# Altium schematic sheet Peripherals.SchDoc(Peripherals)
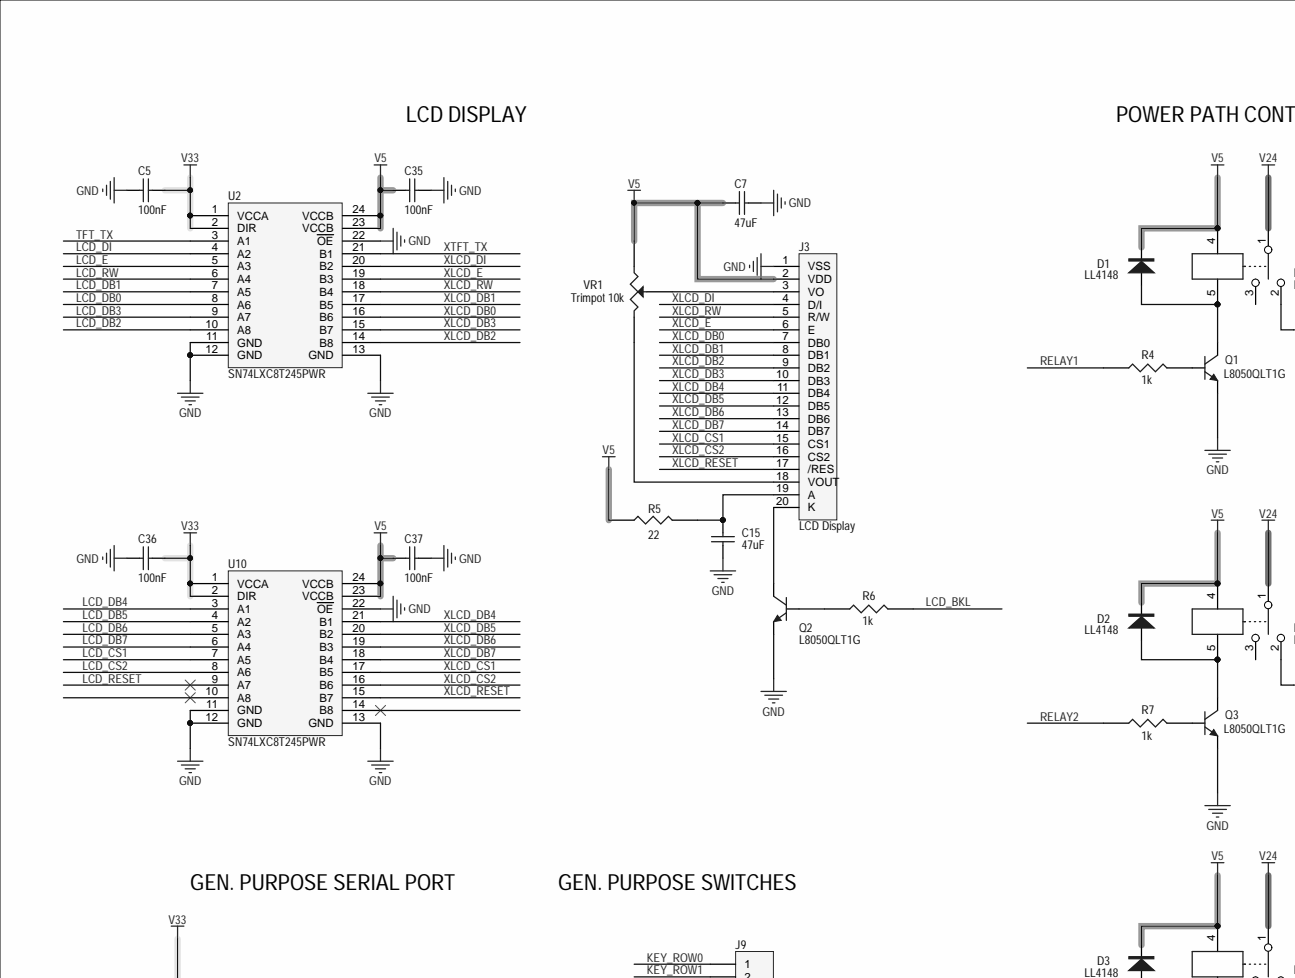
[diagram p0: Peripherals.SchDoc, top left]
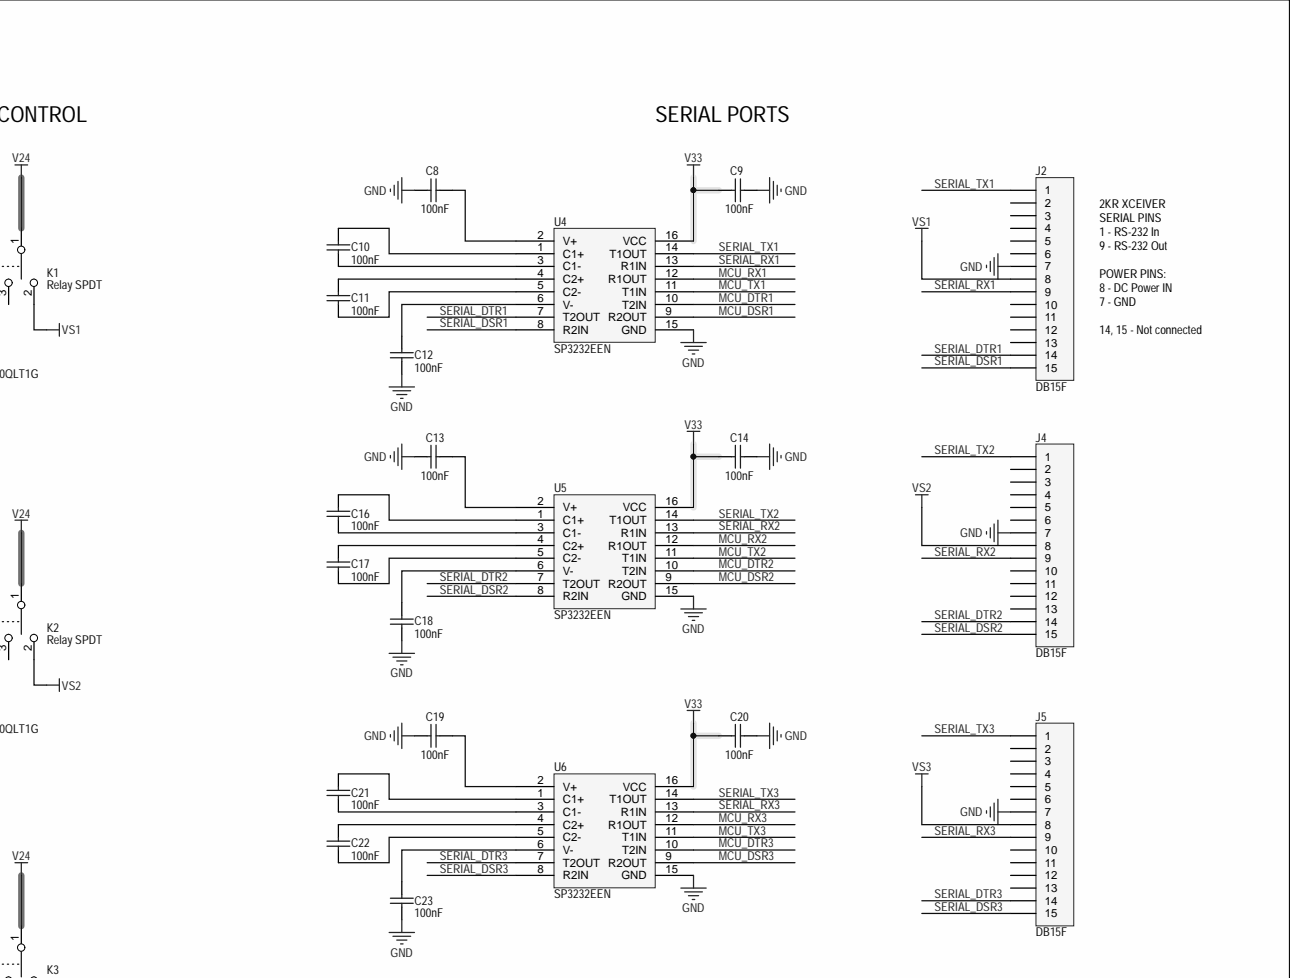
[diagram p1: Peripherals.SchDoc, top right]
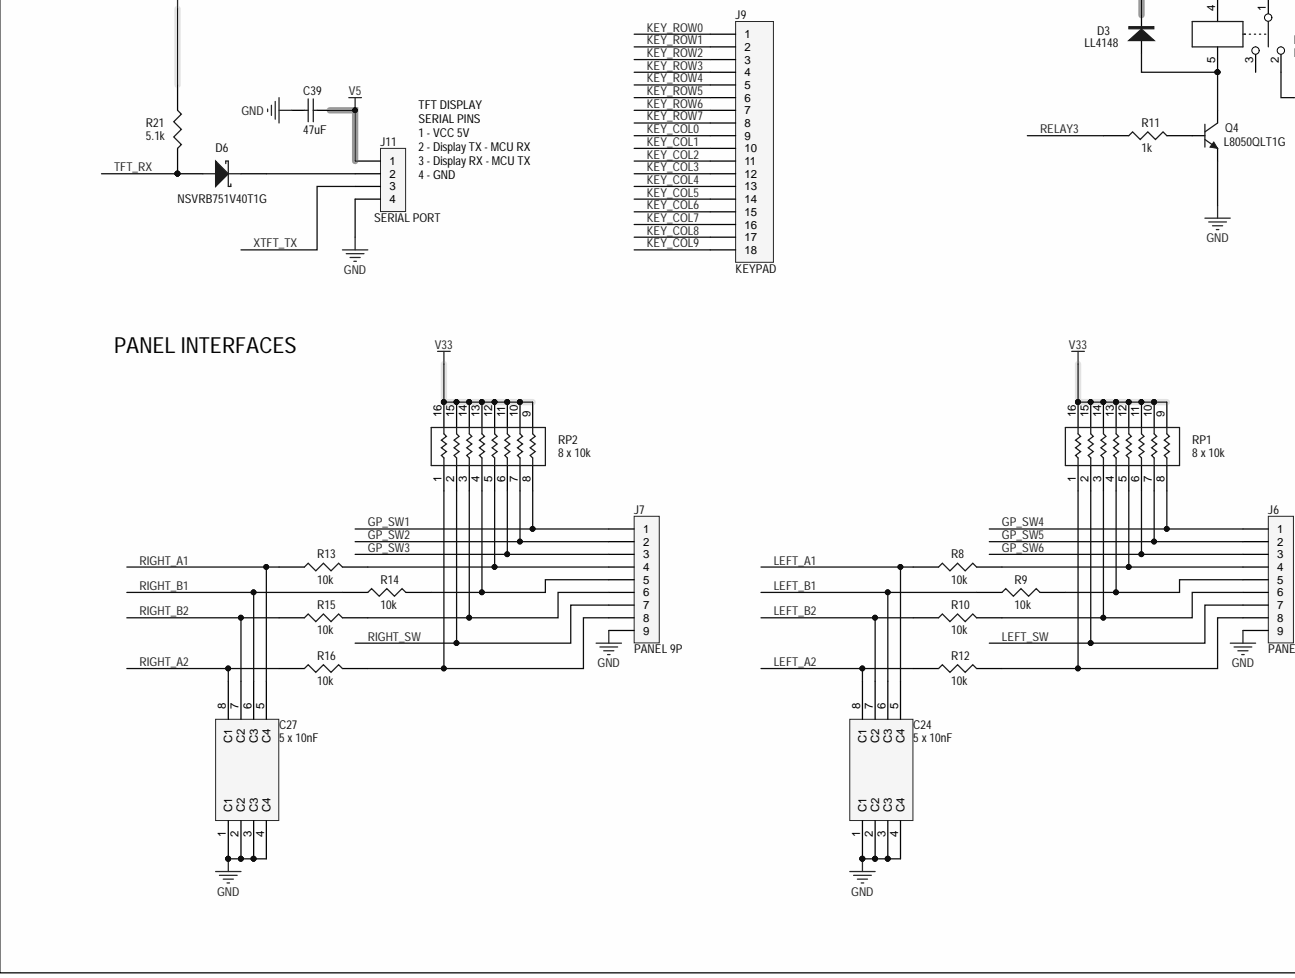
[diagram p2: Peripherals.SchDoc, bottom left]
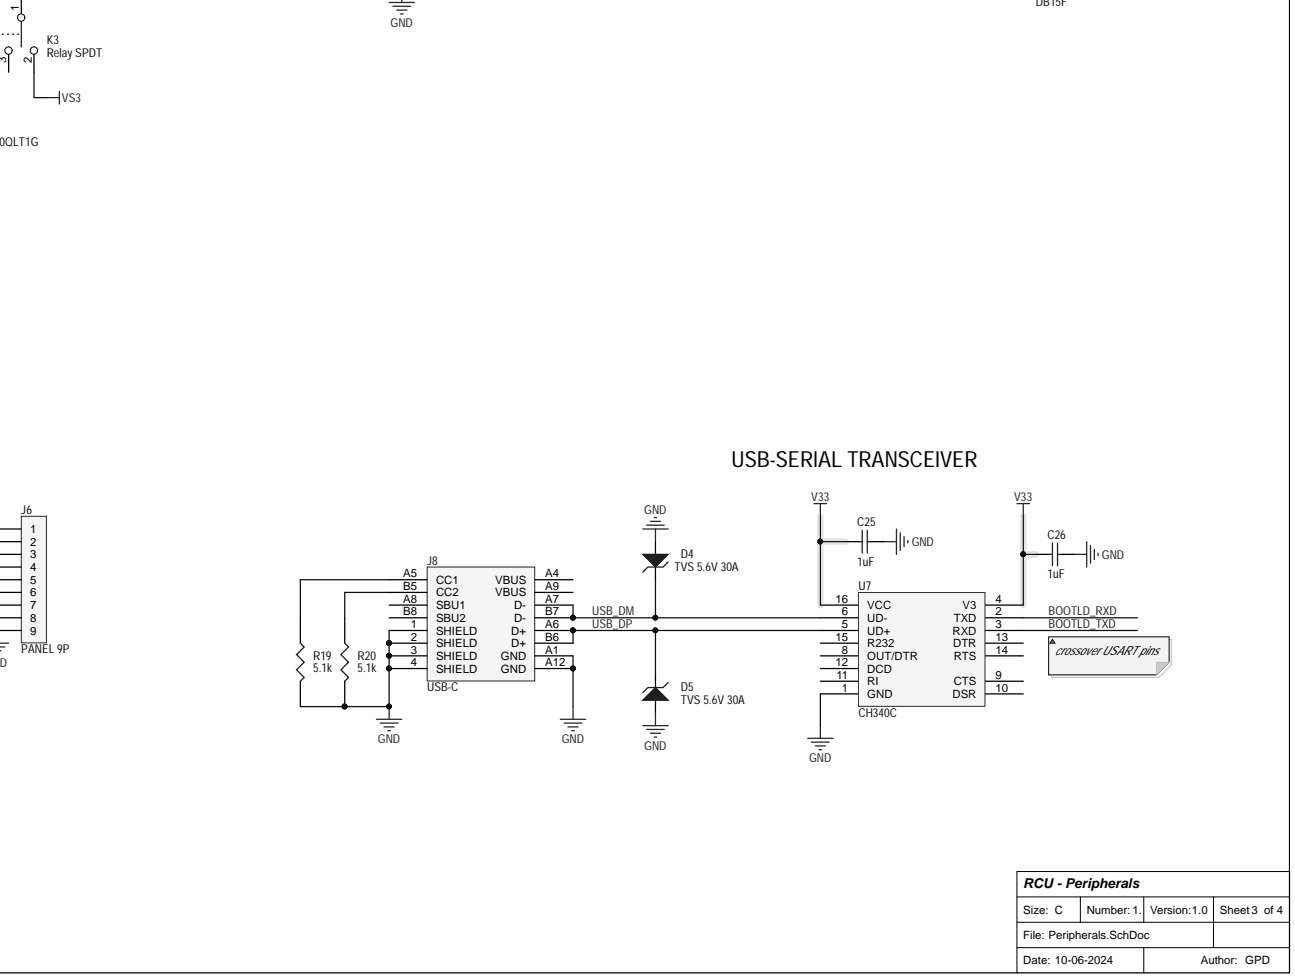
[diagram p3: Peripherals.SchDoc, bottom right]

## Parts
C5  100nF  [C0402]
C7  47uF  [C1210]
C8  100nF  [C0402]
C9  100nF  [C0402]
C10  100nF  [C0402]
C11  100nF  [C0402]
C12  100nF  [C0402]
C13  100nF  [C0402]
C14  100nF  [C0402]
C15  47uF  [C1210]
C16  100nF  [C0402]
C17  100nF  [C0402]
C18  100nF  [C0402]
C19  100nF  [C0402]
C20  100nF  [C0402]
C21  100nF  [C0402]
C22  100nF  [C0402]
C23  100nF  [C0402]
C24  5 x 10nF  [RESPACK08_0612]
C25  1uF  [C0402]
C26  1uF  [C0402]
C27  5 x 10nF  [RESPACK08_0612]
C35  100nF  [C0402]
C36  100nF  [C0402]
C37  100nF  [C0402]
C39  47uF  [C1210]
D1  LL4148  [SOD123]
D2  LL4148  [SOD123]
D3  LL4148  [SOD123]
D4  TVS 5.6V 30A  [SOD523]
D5  TVS 5.6V 30A  [SOD523]
D6  NSVRB751V40T1G  [SOD323]
J2  DB15F  [DB15FLE]
J3  LCD Display  [IDC_20_V]
J4  DB15F  [DB15FLE]
J5  DB15F  [DB15FLE]
J6  PANEL 9P  [JST-9P]
J7  PANEL 9P  [JST-9P]
J8  USB-C  [USB_C_2]
J9  KEYPAD  [HDR2X9]
J11  SERIAL PORT  [HDR1X4]
K1  Relay SPDT  [RELAY_AT1RC5V]
K2  Relay SPDT  [RELAY_AT1RC5V]
K3  Relay SPDT  [RELAY_AT1RC5V]
Q1  L8050QLT1G  [NPN_SMT]
Q2  L8050QLT1G  [NPN_SMT]
Q3  L8050QLT1G  [NPN_SMT]
Q4  L8050QLT1G  [NPN_SMT]
R4  1k  [R0402]
R5  22  [R0805]
R6  1k  [R0402]
R7  1k  [R0402]
R8  10k  [R0402]
R9  10k  [R0402]
R10  10k  [R0402]
R11  1k  [R0402]
R12  10k  [R0402]
R13  10k  [R0402]
R14  10k  [R0402]
R15  10k  [R0402]
R16  10k  [R0402]
R19  5.1k  [R0402]
R20  5.1k  [R0402]
R21  5.1k  [R0402]
RP1  8 x 10k  [RESPACK16_0402]
RP2  8 x 10k  [RESPACK16_0402]
U2  SN74LXC8T245PWR  [TSSO8X6-G24/Z7.2]
U4  SP3232EEN  [SO-G16]
U5  SP3232EEN  [SO-G16]
U6  SP3232EEN  [SO-G16]
U7  CH340C  [SOP16]
U10  SN74LXC8T245PWR  [TSSO8X6-G24/Z7.2]
VR1  Trimpot 10k  [POT1]

## Nets
GND: C5.2 C7.1 C8.2 C9.1 C12.2 C13.2 C14.1 C15.1 C18.2 C19.2 C20.1 C23.2 C24.1(C1) C24.2(C2) C24.3(C3) C24.4(C4) C25.2 C26.2 C27.1(C1) C27.2(C2) C27.3(C3) C27.4(C4) C35.1 C36.2 C37.1 C39.1 D4.1 D5.1 J2.7 J3.1(VSS) J4.7 J5.7 J6.9 J7.9 J8.1(SHIELD) J8.2(SHIELD) J8.3(SHIELD) J8.4(SHIELD) J8.A1(GND) J8.A12(GND) J11.4 Q1.3 Q2.3 Q3.3 Q4.3 R19.2 R20.2 U2.11(GND) U2.12(GND) U2.13(GND) U2.22(OE) U4.15(GND) U5.15(GND) U6.15(GND) U7.1(GND) U10.11(GND) U10.12(GND) U10.13(GND) U10.22(OE)
V5: C7.2 C35.2 C37.2 C39.2 D1.2 D2.2 D3.2 J3.2(VDD) J11.1 K1.4 K2.4 K3.4 R5.1 U2.23(VCCB) U2.24(VCCB) U10.23(VCCB) U10.24(VCCB) VR1.2
V24: K1.1 K2.1 K3.1
V33: C5.1 C9.2 C14.2 C20.2 C25.1 C26.1 C36.1 R21.1 RP1.9 RP1.10 RP1.11 RP1.12 RP1.13 RP1.14 RP1.15 RP1.16 RP2.9 RP2.10 RP2.11 RP2.12 RP2.13 RP2.14 RP2.15 RP2.16 U2.1(VCCA) U2.2(DIR) U4.16(VCC) U5.16(VCC) U6.16(VCC) U7.4(V3) U7.16(VCC) U10.1(VCCA) U10.2(DIR)
VS1: J2.8 K1.2
VS2: J4.8 K2.2
VS3: J5.8 K3.2
BOOTLD_RXD: U7.2(TXD)
BOOTLD_TXD: U7.3(RXD)
GP_SW1: J7.1 RP2.8
GP_SW2: J7.2 RP2.7
GP_SW3: J7.3 RP2.6
GP_SW4: J6.1 RP1.8
GP_SW5: J6.2 RP1.7
GP_SW6: J6.3 RP1.6
KEY_COL0: J9.9
KEY_COL1: J9.10
KEY_COL2: J9.11
KEY_COL3: J9.12
KEY_COL4: J9.13
KEY_COL5: J9.14
KEY_COL6: J9.15
KEY_COL7: J9.16
KEY_COL8: J9.17
KEY_COL9: J9.18
KEY_ROW0: J9.1
KEY_ROW1: J9.2
KEY_ROW2: J9.3
KEY_ROW3: J9.4
KEY_ROW4: J9.5
KEY_ROW5: J9.6
KEY_ROW6: J9.7
KEY_ROW7: J9.8
LCD_BKL: R6.2
LCD_CS1: U10.7(A5)
LCD_CS2: U10.8(A6)
LCD_DB0: U2.8(A6)
LCD_DB1: U2.7(A5)
LCD_DB2: U2.10(A8)
LCD_DB3: U2.9(A7)
LCD_DB4: U10.3(A1)
LCD_DB5: U10.4(A2)
LCD_DB6: U10.5(A3)
LCD_DB7: U10.6(A4)
LCD_DI: U2.4(A2)
LCD_E: U2.5(A3)
LCD_RESET: U10.9(A7)
LCD_RW: U2.6(A4)
LEFT_A1: C24.5(C4) R8.1
LEFT_A2: C24.8(C1) R12.1
LEFT_B1: C24.6(C3) R9.1
LEFT_B2: C24.7(C2) R10.1
LEFT_SW: J6.7 RP1.2
MCU_DSR1: U4.9(R2OUT)
MCU_DSR2: U5.9(R2OUT)
MCU_DSR3: U6.9(R2OUT)
MCU_DTR1: U4.10(T2IN)
MCU_DTR2: U5.10(T2IN)
MCU_DTR3: U6.10(T2IN)
MCU_RX1: U4.12(R1OUT)
MCU_RX2: U5.12(R1OUT)
MCU_RX3: U6.12(R1OUT)
MCU_TX1: U4.11(T1IN)
MCU_TX2: U5.11(T1IN)
MCU_TX3: U6.11(T1IN)
RELAY1: R4.1
RELAY2: R7.1
RELAY3: R11.1
RIGHT_A1: C27.5(C4) R13.1
RIGHT_A2: C27.8(C1) R16.1
RIGHT_B1: C27.6(C3) R14.1
RIGHT_B2: C27.7(C2) R15.1
RIGHT_SW: J7.7 RP2.2
SERIAL_DSR1: J2.15 U4.8(R2IN)
SERIAL_DSR2: J4.15 U5.8(R2IN)
SERIAL_DSR3: J5.15 U6.8(R2IN)
SERIAL_DTR1: J2.14 U4.7(T2OUT)
SERIAL_DTR2: J4.14 U5.7(T2OUT)
SERIAL_DTR3: J5.14 U6.7(T2OUT)
SERIAL_RX1: J2.9 U4.13(R1IN)
SERIAL_RX2: J4.9 U5.13(R1IN)
SERIAL_RX3: J5.9 U6.13(R1IN)
SERIAL_TX1: J2.1 U4.14(T1OUT)
SERIAL_TX2: J4.1 U5.14(T1OUT)
SERIAL_TX3: J5.1 U6.14(T1OUT)
TFT_RX: D6.1 R21.2
TFT_TX: U2.3(A1)
USB_DM: D4.2 J8.A7(D-) J8.B7(D-) U7.6(UD-)
USB_DP: D5.2 J8.A6(D+) J8.B6(D+) U7.5(UD+)
XLCD_CS1: J3.15(CS1) U10.17(B5)
XLCD_CS2: J3.16(CS2) U10.16(B6)
XLCD_DB0: J3.7(DB0) U2.16(B6)
XLCD_DB1: J3.8(DB1) U2.17(B5)
XLCD_DB2: J3.9(DB2) U2.14(B8)
XLCD_DB3: J3.10(DB3) U2.15(B7)
XLCD_DB4: J3.11(DB4) U10.21(B1)
XLCD_DB5: J3.12(DB5) U10.20(B2)
XLCD_DB6: J3.13(DB6) U10.19(B3)
XLCD_DB7: J3.14(DB7) U10.18(B4)
XLCD_DI: J3.4(D/I) U2.20(B2)
XLCD_E: J3.6(E) U2.19(B3)
XLCD_RESET: J3.17(/RES) U10.15(B7)
XLCD_RW: J3.5(R/W) U2.18(B4)
XTFT_TX: J11.3 U2.21(B1)
NetC8_1: C8.1 U4.2(V+)
NetC10_1: C10.1 U4.1(C1+)
NetC10_2: C10.2 U4.3(C1-)
NetC11_1: C11.1 U4.4(C2+)
NetC11_2: C11.2 U4.5(C2-)
NetC12_1: C12.1 U4.6(V-)
NetC13_1: C13.1 U5.2(V+)
NetC15_2: C15.2 J3.19(A) R5.2
NetC16_1: C16.1 U5.1(C1+)
NetC16_2: C16.2 U5.3(C1-)
NetC17_1: C17.1 U5.4(C2+)
NetC17_2: C17.2 U5.5(C2-)
NetC18_1: C18.1 U5.6(V-)
NetC19_1: C19.1 U6.2(V+)
NetC21_1: C21.1 U6.1(C1+)
NetC21_2: C21.2 U6.3(C1-)
NetC22_1: C22.1 U6.4(C2+)
NetC22_2: C22.2 U6.5(C2-)
NetC23_1: C23.1 U6.6(V-)
NetD1_1: D1.1 K1.5 Q1.1
NetD2_1: D2.1 K2.5 Q3.1
NetD3_1: D3.1 K3.5 Q4.1
NetD6_2: D6.2 J11.2
NetJ3_3: J3.3(VO) VR1.3
NetJ3_18: J3.18 VR1.1
NetJ3_20: J3.20(K) Q2.1
NetJ6_4: J6.4 R8.2 RP1.5
NetJ6_5: J6.5 R9.2 RP1.4
NetJ6_6: J6.6 R10.2 RP1.3
NetJ6_8: J6.8 R12.2 RP1.1
NetJ7_4: J7.4 R13.2 RP2.5
NetJ7_5: J7.5 R14.2 RP2.4
NetJ7_6: J7.6 R15.2 RP2.3
NetJ7_8: J7.8 R16.2 RP2.1
NetJ8_A5: J8.A5(CC1) R19.1
NetJ8_B5: J8.B5(CC2) R20.1
NetQ1_2: Q1.2 R4.2
NetQ2_2: Q2.2 R6.1
NetQ3_2: Q3.2 R7.2
NetQ4_2: Q4.2 R11.2

Not connected: J2 2 3 4 5 6 10 11 12 13; J4 2 3 4 5 6 10 11 12 13; J5 2 3 4 5 6 10 11 12 13; J8 A4(VBUS) A8(SBU1) A9(VBUS) B8(SBU2); K1 3; K2 3; K3 3; U7 8(OUT/DTR) 9(CTS) 10(DSR) 11(RI) 12(DCD) 13(DTR) 14(RTS) 15(R232); U10 10(A8) 14(B8)

## Library data of the parts
C5 C8-C14 C16-C23 C35-C37 = CAP: 100nF ±10% 16V X7R 0402 Multilayer Ceramic Capacitors MLCC - SMD/SMT RoHS; Manufacturer Part Number: C0402C104K4RAC-TU; Manufacturer: KEMET; Value: 100nF; Supplier Part Number 3: 399-C0402C104K4RAC7867DKR-ND; Supplier Part Number 2: 8015145; Supplier Part Number 1: 80-C0402C104K4R; Supplier 3: Digikey; Supplier 2: Rs; Supplier 1: Mouser; Library Name: Miscellaneous Devices.SchLib; Component Kind: Standard
C7 C15 C39 = CAP: Multilayer Ceramic Capacitor, 47 uF, 16 V, ± 10%, X5R, 1210 [3225 Metric]; Manufacturer Part Number: CL32A476KOJNNNE; Manufacturer: Samsung; Value: 47uF; Supplier Part Number 3: 1276-3376-1-ND; Supplier Part Number 2: C2932477; Supplier Part Number 1: 187-CL32A476KOJNNNE; Supplier 3: Digikey; Supplier 2: LCSC; Supplier 1: Mouser; Library Name: Miscellaneous Devices.SchLib; Component Kind: Standard
C24 C27 = PIC12F629/675: Cap Ceramic Array 0.01uF 100V X7R 20% 0612 SMD 4 Capacitor 125°C Paper T/R/Embossed T/R; Manufacturer Part Number: W3A41C103MAT2A; Manufacturer: Kyocera AVX; Value: 5 x 10nF; Supplier Part Number 3: 3340903RL; Supplier Part Number 2: 581-W3A41C103MAT2A; Supplier Part Number 1: 478-2871-6-ND; Supplier 3: Farnell; Supplier 2: Mouser; Supplier 1: Digikey; Library Name: PICs.SchLib; Component Kind: Standard
C25 C26 = CAP: Multilayer Ceramic Capacitor, 1 uF, 10 V, ± 10%, X5R, 0402 [1005 Metric]; Manufacturer Part Number: CC0402KRX5R6BB105; Manufacturer: Yageo; Value: 1uF; Supplier Part Number 3: 603-CC402KRX5R6BB105; Supplier Part Number 2: 311-1439-6-ND; Supplier Part Number 1: 311-1439-1-ND; Supplier 3: Mouser; Supplier 2: Digikey; Supplier 1: Digikey; Library Name: Miscellaneous Devices.SchLib; Component Kind: Standard
D1-D3 = 1N4148: Rectifier Diode Small Signal Switching 100V 0.15A 4ns 2-Pin Mini-MELF T/R; Manufacturer: Diotec; Value: LL4148; Supplier Part Number 3: 637-LL4148; Supplier Part Number 2: 4878-LL4148DKR-ND; Supplier Part Number 1: 4878-LL4148CT-ND; Supplier 3: Mouser; Supplier 2: Digikey; Supplier 1: Digikey; Simulation: 1N4148; Manufacturer Part Number: LL4148; Component Kind: Standard
D4 D5 = 1N5380B: Epcos B72500D0050A060; Bi-Directional TVS Diode; 1000W; 2-Pin SOD-523; Manufacturer: TDK EPCOS; Value: TVS 5.6V 30A; Supplier Part Number 3: 4962968P; Supplier Part Number 2: 495-3414-6-ND; Supplier Part Number 1: C210787; Supplier 3: Rs; Supplier 2: Digikey; Supplier 1: LCSC; Simulation: 1N5380B; Manufacturer Part Number: B72500D0050A060; Library Name: DIODE.SchLib; Component Kind: Standard
D6 = DIODE SCHOTTKY: NSVRB751V40T1G Series 40 V 30 mA SMT Schottky Barrier Diode - SOT-323; Manufacturer: ON Semiconductor; Value: NSVRB751V40T1G; Supplier Part Number 3: 2844901; Supplier Part Number 2: NSVRB751V40T1GOSDKR-ND; Supplier Part Number 1: 863-NSVRB751V40T1G; Supplier 3: Farnell; Supplier 2: Digikey; Supplier 1: Mouser; Manufacturer Part Number: NSVRB751V40T1G; Library Name: Miscellaneous Devices.SchLib; Component Kind: Standard
J2 J4 J5 = CON15: D Subminiature Connector, 15 Contact(S), Female, 0.108 Inch Pitch, Solder Terminal, M3, Socket; Manufacturer Part Number: D15S13A4GL00LF; Manufacturer: Amphenol Communications Solutions; Value: DB15F; Supplier Part Number 3: 649-D15S13A4GL00LF; Supplier Part Number 2: 252377; Supplier Part Number 1: 609-1494-ND; Supplier 3: Mouser; Supplier 2: Rs; Supplier 1: Digikey; Library Name: Miscellaneous Devices.SchLib; Component Kind: Standard
J3 = CON20: Conn Shrouded Header (4 Sides) HDR 10 POS 2.54mm Solder ST Top Entry Thru-Hole Tray; Manufacturer Part Number: BHR-10-VUA; Manufacturer: Adam Equipment; Value: LCD Display; Supplier Part Number 3: BHR-10-VUA; Supplier Part Number 2: 2057-BHR-10-VUA-ND; Supplier Part Number 1: 737-BHR-10-VUA; Supplier 3: Verical; Supplier 2: Digikey; Supplier 1: Mouser; Library Name: Miscellaneous Devices.SchLib; Component Kind: Standard
J6 J7 = CON9: Connector Header, PH Series, 9 Position, 2.00mm, Through Hole, Press-Fit, Solder, Natural; Manufacturer Part Number: B9B-PH-K-S(LF)(SN); Manufacturer: JST; Value: PANEL 9P; Supplier Part Number 3: 8201453; Supplier Part Number 2: 455-1711-ND; Supplier Part Number 1: C157968; Supplier 3: Rs; Supplier 2: Digikey; Supplier 1: LCSC; Library Name: Miscellaneous Devices.SchLib; Component Kind: Standard
J8 = USB-C-CONN2: USB 2.0 5A 1 Surface Mount，Right Angle 16P Female -40℃~+85℃ Gold High Conductivity Copper Type-C SMD USB Connectors ROHS; Manufacturer Part Number: GT-USB-7047B; Manufacturer: G-Switch; Value: USB-C; Supplier Part Number 3: C963217; Supplier Part Number 2: C963217; Supplier Part Number 1: C963217; Supplier 3: LCSC; Supplier 2: LCSC; Supplier 1: LCSC; Component Kind: Standard; Library Name: Varios.SchLib
J9 = CON18: Dubox, Board To Board Connector, Receptacle, Vertical, Through Hole, Top Entry, Double Row , 18 Positions, 2.54mm...; Manufacturer Part Number: 76342-409LF; Manufacturer: Amphenol ICC / FCI; Value: KEYPAD; Supplier Part Number 2: 649-76342-409LF; Supplier Part Number 1: 76342-409LF-ND; Supplier 2: Mouser; Supplier 1: Digikey; Library Name: Miscellaneous Devices.SchLib; Component Kind: Standard
J11 = CON4: Header Assembly 4 Position MTA100 SPCL BEND MTA-100 Series; Manufacturer Part Number: 644874-4; Manufacturer: TE Connectivity; Value: SERIAL PORT; Supplier Part Number 2: A114209-ND; Supplier Part Number 1: 571-644874-4; Supplier 2: Digikey; Supplier 1: Mouser; Library Name: Miscellaneous Devices.SchLib; Component Kind: Standard
K1-K3 = RELAY-SPDT: SPDT Non Latching Plugin Power Relays Coil 3VDC Contacts 10A 250VAC; Manufacturer Part Number: SRD-05VDC-SL-C; Manufacturer: Ningbo Forward Relay; Value: Relay SPDT; Supplier Part Number 3: C35449; Supplier Part Number 2: C35449; Supplier Part Number 1: C35449; Supplier 3: LCSC; Supplier 2: LCSC; Supplier 1: LCSC; Component Kind: Standard
Q1-Q4 = MMBT3904: 25V 225mW 800mA NPN SOT-23 Bipolar Transistors - BJT ROHS; Manufacturer: LRC; Value: L8050QLT1G; Supplier Part Number 3: C49581; Supplier Part Number 2: C49581; Supplier Part Number 1: C49581; Supplier 3: LCSC; Supplier 2: LCSC; Supplier 1: LCSC; Simulation: MMBT3904; Manufacturer Part Number: L8050QLT1G; Component Kind: Standard
R4 R6 R7 R11 = RES1: SMD Chip Resistor, 1 kOhm, ± 1%, 63 mW, 0402 [1005 Metric], Thick Film, General Purpose; Manufacturer Part Number: CRCW04021K00FKED; Manufacturer: Vishay Dale; Value: 1k; Supplier Part Number 3: C71623; Supplier Part Number 2: 71-CRCW0402-1.0K-E3; Supplier Part Number 1: 541-1.00KLDKR-ND; Supplier 3: LCSC; Supplier 2: Mouser; Supplier 1: Digikey; Component Kind: Standard
R5 = RES1: SMD Chip Resistor, 22 Ohm, ± 1%, 125 mW, 0805 [2012 Metric], Thin Film, High Precision High Stability; Manufacturer Part Number: RT0805FRE0722RL; Manufacturer: Yageo; Value: 22; Supplier Part Number 3: 603-RT0805FRE0722RL; Supplier Part Number 2: 13-RT0805FRE0722RLCT-ND; Supplier Part Number 1: 13-RT0805FRE0722RLDKR-ND; Supplier 3: Mouser; Supplier 2: Digikey; Supplier 1: Digikey; Component Kind: Standard
R8-R10 R12-R16 = RES1: SMD Chip Resistor, 10 kOhm, ± 5%, 63 mW, 0402 [1005 Metric], Thick Film, General Purpose; Manufacturer Part Number: CRCW040210K0JNED; Manufacturer: Vishay Dale; Value: 10k; Supplier Part Number 3: 541-10KJDKR-ND; Supplier Part Number 2: C191984; Supplier Part Number 1: 71-CRCW0402J-10K-E3; Supplier 3: Digikey; Supplier 2: LCSC; Supplier 1: Mouser; Library Name: Miscellaneous Devices.SchLib; Component Kind: Standard
R19-R21 = RES1: SMD Chip Resistor, 5.1 kOhm, ± 5%, 63 mW, 0402 [1005 Metric], Thick Film, General Purpose; Manufacturer Part Number: RC0402JR-075K1L; Manufacturer: Yageo; Value: 5.1k; Supplier Part Number 3: C105873; Supplier Part Number 2: 311-5.1KJRCT-ND; Supplier Part Number 1: 603-RC0402JR-075K1L; Supplier 3: LCSC; Supplier 2: Digikey; Supplier 1: Mouser; Library Name: Miscellaneous Devices.SchLib; Component Kind: Standard
RP1 RP2 = RESPACK3: Res Thick Film Array 10K Ohm 5% 1/4W ±200ppm/°C ISOL Molded 16-Pin 1506(8 X 0402) Convex SMD Punched Carrier T/R; Manufacturer Part Number: EXB-2HV103JV; Manufacturer: Panasonic; Value: 8 x 10k; Supplier Part Number 3: Y1103DKR-ND; Supplier Part Number 2: C713454; Supplier Part Number 1: 667-EXB-2HV103JV; Supplier 3: Digikey; Supplier 2: LCSC; Supplier 1: Mouser; Library Name: Miscellaneous Devices.SchLib; Component Kind: Standard
U2 U10 = SN74LXC8T245: 8-bit dual-supply bus transceiver with configurable voltage-level shifting and three-state outputs 24-TSSOP -40 to 125; Manufacturer: Texas Instruments; Value: SN74LXC8T245PWR; Supplier Part Number 3: SN74LXC8T245PWR; Supplier Part Number 2: 296-SN74LXC8T245PWRDKR-ND; Supplier Part Number 1: 595-SN74LXC8T245PWR; Supplier 3: Texas Instruments; Supplier 2: Digikey; Supplier 1: Mouser; Manufacturer Part Number: SN74LXC8T245PWR; Library Name: ICs.SchLib; ComponentLink1URL: https://www.ti.com/lit/ds/symlink/sn74lxc8t245.pdf; ComponentLink1Description: Datasheet; Component Kind: Standard
U4-U6 = MAX3232: SOP-16 RS232 ICs ROHS; Manufacturer: UMW; Value: SP3232EEN; Supplier Part Number 3: 4518-SP3232EENCT-ND; Supplier Part Number 2: 4518-SP3232EENDKR-ND; Supplier Part Number 1: C2904739; Supplier 3: Digikey; Supplier 2: Digikey; Supplier 1: LCSC; Manufacturer Part Number: SP3232EEN; Library Name: ICs.SchLib; ComponentLink1URL: https://www.lcsc.com/datasheet/lcsc_datasheet_2402231528_UMW-Youtai-Semiconductor-Co---Ltd--SP3232EEN_C2904739.pdf; ComponentLink1Description: Datasheet; Component Kind: Standard
U7 = CH340C: Transceiver 2Mbps USB 2.0 SOP-16_150mil USB Ics ROHS; Manufacturer: WCH; Value: CH340C; Supplier Part Number 3: C84681; Supplier Part Number 2: C84681; Supplier Part Number 1: C7464026; Supplier 3: LCSC; Supplier 2: LCSC; Supplier 1: LCSC; Manufacturer Part Number: CH340C; Library Name: ICs.SchLib; ComponentLink1URL: https://cdn.sparkfun.com/datasheets/Dev/Arduino/Other/CH340DS1.PDF; ComponentLink1Description: Datasheet; Component Kind: Standard
VR1 = POT1: Cermet trimmer potentiometer, 10 kΩ, 0.5 W, THT, on top; Manufacturer Part Number: 3362P-1-103LF; Manufacturer: Bourns; Value: Trimpot 10k; Supplier Part Number 3: C58159; Supplier Part Number 2: 652-3362P-1-103LF; Supplier Part Number 1: 3362P-103LF-ND; Supplier 3: LCSC; Supplier 2: Mouser; Supplier 1: Digikey; Library Name: Miscellaneous Devices.SchLib; Component Kind: Standard
